# Altium schematic sheet POE.SchDoc
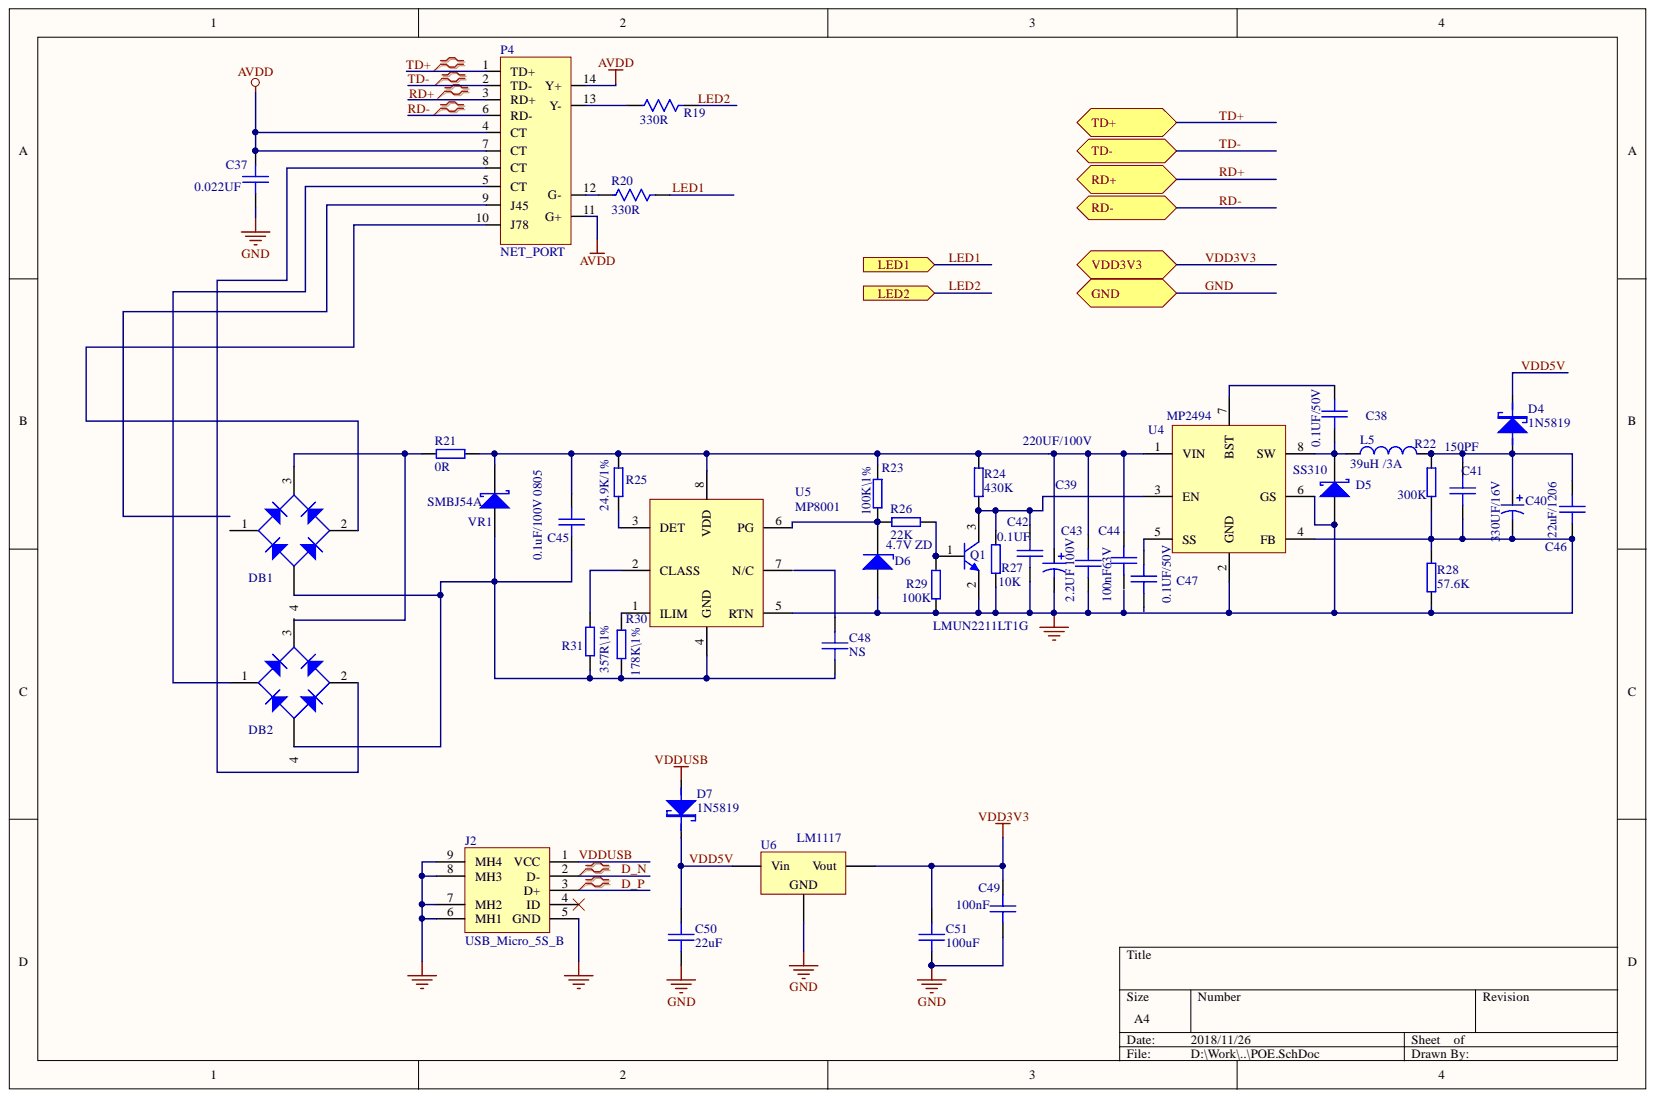
[diagram p0: POE.SchDoc]

## Parts
C37  0.022UF  [EIA3216]
C38  0.1UF/50V  [0603C]
C39  220UF/100V  [CAPC2012L]
C40  330UF/16V  [CAPC2012L]
C41  150PF  [0603C]
C42  0.1UF  [0603C]
C43  2.2UF 100V  [1206-CAP]
C44  100nF63V  [0805]
C45  0.1uF/100V 0805  [0805]
C46  22uF/1206  [1206-CAP]
C47  0.1UF/50V  [0603C]
C48  NS  [0603C]
C49  100nF  [0603C]
C50  22uF  [1206-CAP]
C51  100uF  [EIA3216]
D4  1N5819  [SOD-323]
D5  SS310  [DO-214AA]
D6  4.7V ZD  [DIODE-1206]
D7  1N5819  [SOD-323]
DB1  MB10F  [DIODE-SMA]  (value not drawn)
DB2  MB10F  [DIODE-SMA]  (value not drawn)
J2  USB_Micro_5S_B  [FCI_10118194-0001]
L5  39uH /3A  [INDC1005AL]
P4  NET_PORT  [9003GYLNL]
Q1  LMUN2211LT1G  [SOT-23]
R19  330R  [CC0805]
R20  330R  [CC0805]
R21  0R  [1206]
R22  300K  [0603R]
R23  100K\1%  [0603R]
R24  430K  [0603R]
R25  24.9K/1%  [0603R]
R26  22K  [0603R]
R27  10K  [0603R]
R28  57.6K  [0603R]
R29  100K  [0603R]
R30  178K\1%  [0603R]
R31  357R\1%  [0603R]
U4  MP2494  [SOIC8_N]
U5  MP8001  [SOIC8_N]
U6  LM1117  [TO254P1510-3_4N]
VR1  SMBJ54A  [DO-214AA]

## Ports
GND, LED1, LED2, RD+, RD-, TD+, TD-, VDD3V3

## Nets
AVDD: C37.1 P4.4(CT) P4.7(CT) P4.11(G+) P4.14(Y+)
D_N: J2.2(D-)
D_P: J2.3(D+)
GND: C37.2 C40.2 C41.2 C42.2 C43.2 C46.2 C49.2 C50.2 C51.2 D5.1 D6.1 J2.5(GND) J2.6(MH1) J2.7(MH2) J2.8(MH3) J2.9(MH4) Q1.2 R22.2 R27.1 R28.1 R28.2 R29.1 U4.2(GND) U4.4(FB) U4.6(GS) U5.5(RTN) U6.2 ; port GND
LED1: R20.1 ; port LED1
LED2: R19.1 ; port LED2
RD-: P4.6(RD-) ; port RD-
TD+: P4.1(TD+) ; port TD+
TD-: P4.2(TD-) ; port TD-
VDD3V3: C49.1 C51.1 U6.3 ; port VDD3V3
VDD5V: C50.1 D4.2 D7.2 U6.1
VDDUSB: D7.1 J2.1(VCC)
NetC38_1: C38.1 U4.7(BST)
NetC38_2: C38.2 D5.2 L5.1 U4.8(SW)
NetC39_1: C39.1 C43.1 C44.1 C45.1 R21.1 R23.2 R24.2 R25.2 U4.1(VIN) U5.8(VDD) VR1.2
NetC40_1: C40.1 C41.1 C46.1 L5.2 R22.1
NetC42_1: C42.1 Q1.3 R24.1 R27.2 U4.3(EN)
NetC45_2: C45.2 C48.1 R30.1 R31.1 U5.4(GND) VR1.1
NetC47_1: C47.1 U4.5(SS)
NetD6_2: D6.2 R23.1 R26.1 U5.6(PG)
NetDB1_2: DB1.2 P4.10(J78)
NetDB1_3: DB1.3 R21.2
NetDB2_2: DB2.2 P4.8(CT)
NetP4_12: P4.12(G-) R20.2
NetP4_13: P4.13(Y-) R19.2
NetQ1_1: Q1.1 R26.2 R29.2
NetR25_1: R25.1 U5.3(DET)
NetR30_2: R30.2 U5.1(ILIM)
NetR31_2: R31.2 U5.2(CLASS)
N00143:
RD+: ; port RD+

Not connected: C39 2; C44 2; C47 2; C48 2; D4 1; DB1 1 4; DB2 1 3 4; J2 4(ID); P4 3(RD+) 5(CT) 9(J45); U5 7(N/C)

## Library data of the parts
C37 C38 C42-C51 = SMT_C_0402: Surface mount capacitor 0402; Component Kind: Standard; Library Name: Vowstar_Basic_Device.IntLib
C39 C40 = Cap Pol3: Polarized Capacitor (Surface Mount); Value: 100pF; Component Kind: Standard; LatestRevisionDate: 29-May-2009; LatestRevisionNote: IPC-7351 Footprint Added.; Library Name: Miscellaneous Devices.IntLib; PackageDescription: Chip Capacitor; PackageReference: C0805; Published: 8-Jun-2000; Signal Integrity: Cap Pol; Simulation: CAP; Publisher: Altium Limited
C41 = SMT_C_0402: 21; Component Kind: Standard; Library Name: Vowstar_Basic_Device.IntLib
D4 D7 = SMT_DIODE_SCHOTTKY_DO_214AC: Surface mount schottky diode DO-214AC(SMA) Package; Component Kind: Standard; Library Name: Vowstar_Basic_Device.IntLib
D5 VR1 = D Schottky: Schottky Diode; Code_JEDEC: DO-214-AA; Component Kind: Standard; LatestRevisionDate: 17-Jul-2002; LatestRevisionNote: Re-released for DXP Platform.; Library Name: Miscellaneous Devices.IntLib; PackageReference: SMB; Published: 8-Jun-2000; Signal Integrity: D Schottky; Simulation: SKYDIODE; Publisher: Altium Limited
D6 = Diode-Z: 稳压二极管; Value: BZT52C4V7-13; Code_JEDEC: DO-214-AA; Component Kind: Standard; LatestRevisionDate: 17-Jul-2002; LatestRevisionNote: Re-released for DXP Platform.; PackageReference: SMB; Published: 8-Jun-2000; Library Name: fszhang.SchLib; Publisher: Altium Limited
DB1 DB2 = MB10F: 整流桥; Code_JEDEC: DO-214-AB; Component Kind: Standard; LatestRevisionDate: 17-Jul-2002; LatestRevisionNote: Re-released for DXP Platform.; PackageDocument: Sep-1996; PackageReference: SMC; Published: 8-Jun-2000; Type: MB10F; Library Name: fszhang.SchLib; Publisher: Altium Limited
J2 = USB_Micro_5S_B: USB micro female SMT with 4 fixed foot; Component Kind: Standard; Library Name: Vowstar_Connector.IntLib
L5 = Inductor: Code_IEC: 1005; Value: 10mH; Code_JEITA: 0402; Component Kind: Standard; Description: Inductor; LatestRevisionDate: 29-May-2009; LatestRevisionNote: IPC-7351 Footprint Added.; Library Name: Miscellaneous Devices.IntLib; PackageDescription: Chip Inductor; PackageReference: 0402-A; Published: 8-Jun-2000; Signal Integrity: Inductor; Simulation: INDUCTOR; Publisher: Altium Limited
Q1 = BCP68: NPN三极管; Component Kind: Standard; ComponentLink1Description: Manufacturer Link; ComponentLink1URL: http://www.semiconductors.philips.com/; ComponentLink2Description: Datasheet; ComponentLink2URL: http://www.semiconductors.philips.com/acrobat/datasheets/BCP68_4.pdf; DatasheetVersion: 8-Apr-1999; LatestRevisionDate: 12-Dec-2006; LatestRevisionNote: IPC-7351 Footprint Added.; PackageDescription: 4-Pin SOT-223 Package 2.3 mm Pitch, 7 mm Lead Span; PackageReference: SOT223; PackageVersion: Aug-2001; Published: 17-Sep-2003; Library Name: fszhang.SchLib; Publisher: Altium Limited
R19 R20 = RESISTOR: Component Kind: Standard; Library Name: Wristband Beacon.SCHLIB
R21-R31 = SMT_R_0402: Surface mount resistor 0402; Component Kind: Standard; Library Name: Vowstar_Basic_Device.IntLib
U4 = MP2494: 2A, 55V, 100kHz Step-Down Converter; Code_IPC: SOIC127P600-8; Component Kind: Standard; ComponentLink1Description: Manufacturer Link; ComponentLink1URL: http://www.ti.com/; DatasheetVersion: Jan-1989; LatestRevisionDate: 13-Apr-2006; LatestRevisionNote: IPC-7351 Footprint Added.; PackageDescription: 8-Pin Small Outline Integrated Circuit 1.27 mm Pitch; PackageReference: D008; PackageVersion: Jan-1998; Published: 15-Apr-2002; Library Name: fszhang.SchLib; Publisher: Altium Limited
U5 = MP8001: Powered Device (PD) Controller; Code_IPC: SOIC127P600-8; Component Kind: Standard; ComponentLink1Description: Manufacturer Link; ComponentLink1URL: http://www.ti.com/; DatasheetVersion: Jan-1989; LatestRevisionDate: 13-Apr-2006; LatestRevisionNote: IPC-7351 Footprint Added.; PackageDescription: 8-Pin Small Outline Integrated Circuit 1.27 mm Pitch; PackageReference: D008; PackageVersion: Jan-1998; Published: 15-Apr-2002; Library Name: fszhang.SchLib; Publisher: Altium Limited
U6 = Volt Reg: Voltage Regulator; Component Kind: Standard; LatestRevisionDate: 29-May-2009; LatestRevisionNote: IPC-7351 Footprint Added.; Library Name: Miscellaneous Devices.IntLib; PackageDescription: 3-Pin Transistor Outline Package 2.54 mm Pitch, 15.1 mm Lead Span; PackageReference: D2PAK; Published: 24-Mar-1999; Publisher: Altium Limited
P4 = NET_PORT: Component Kind: Standard; Library Name: Wristband Beacon.SCHLIB
